# Altium schematic sheet 3_NRF52840.SchDoc(3_NRF52840)
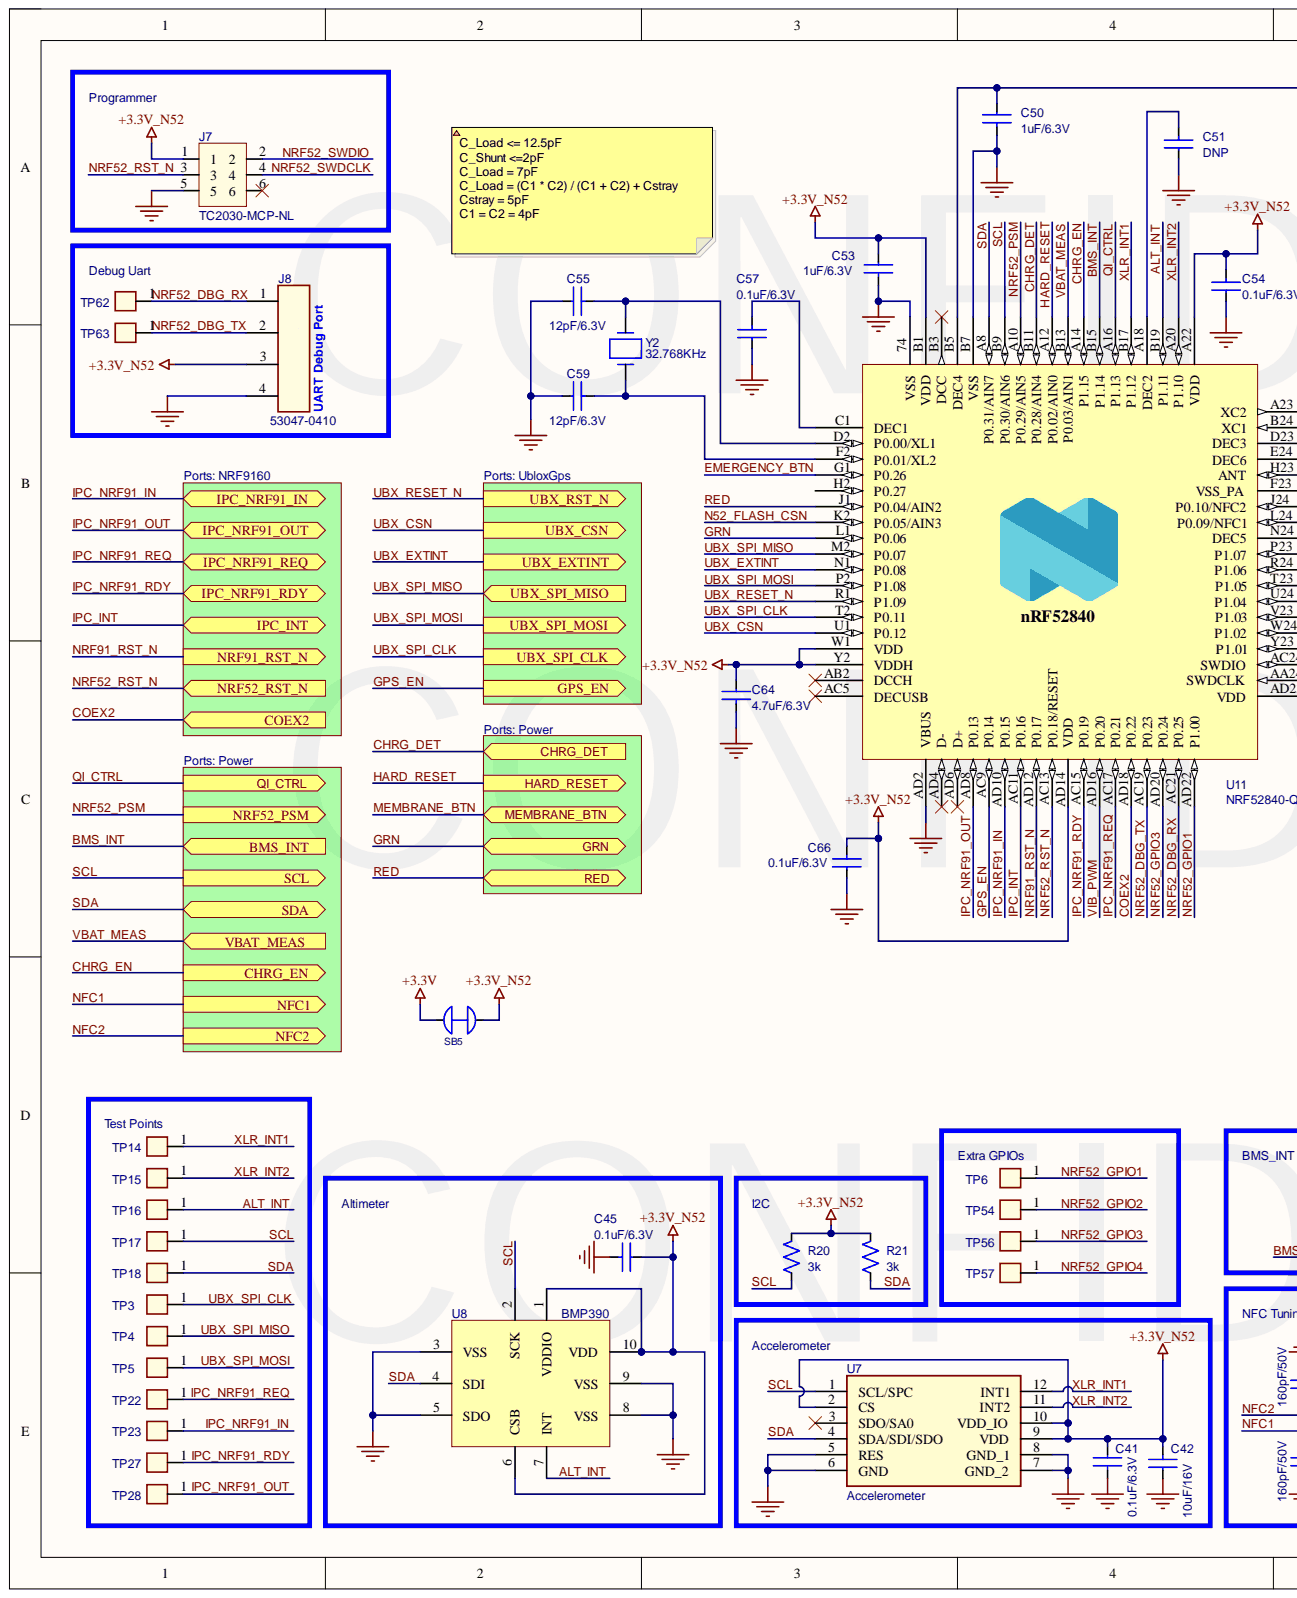
[diagram p0: 3_NRF52840.SchDoc, left]
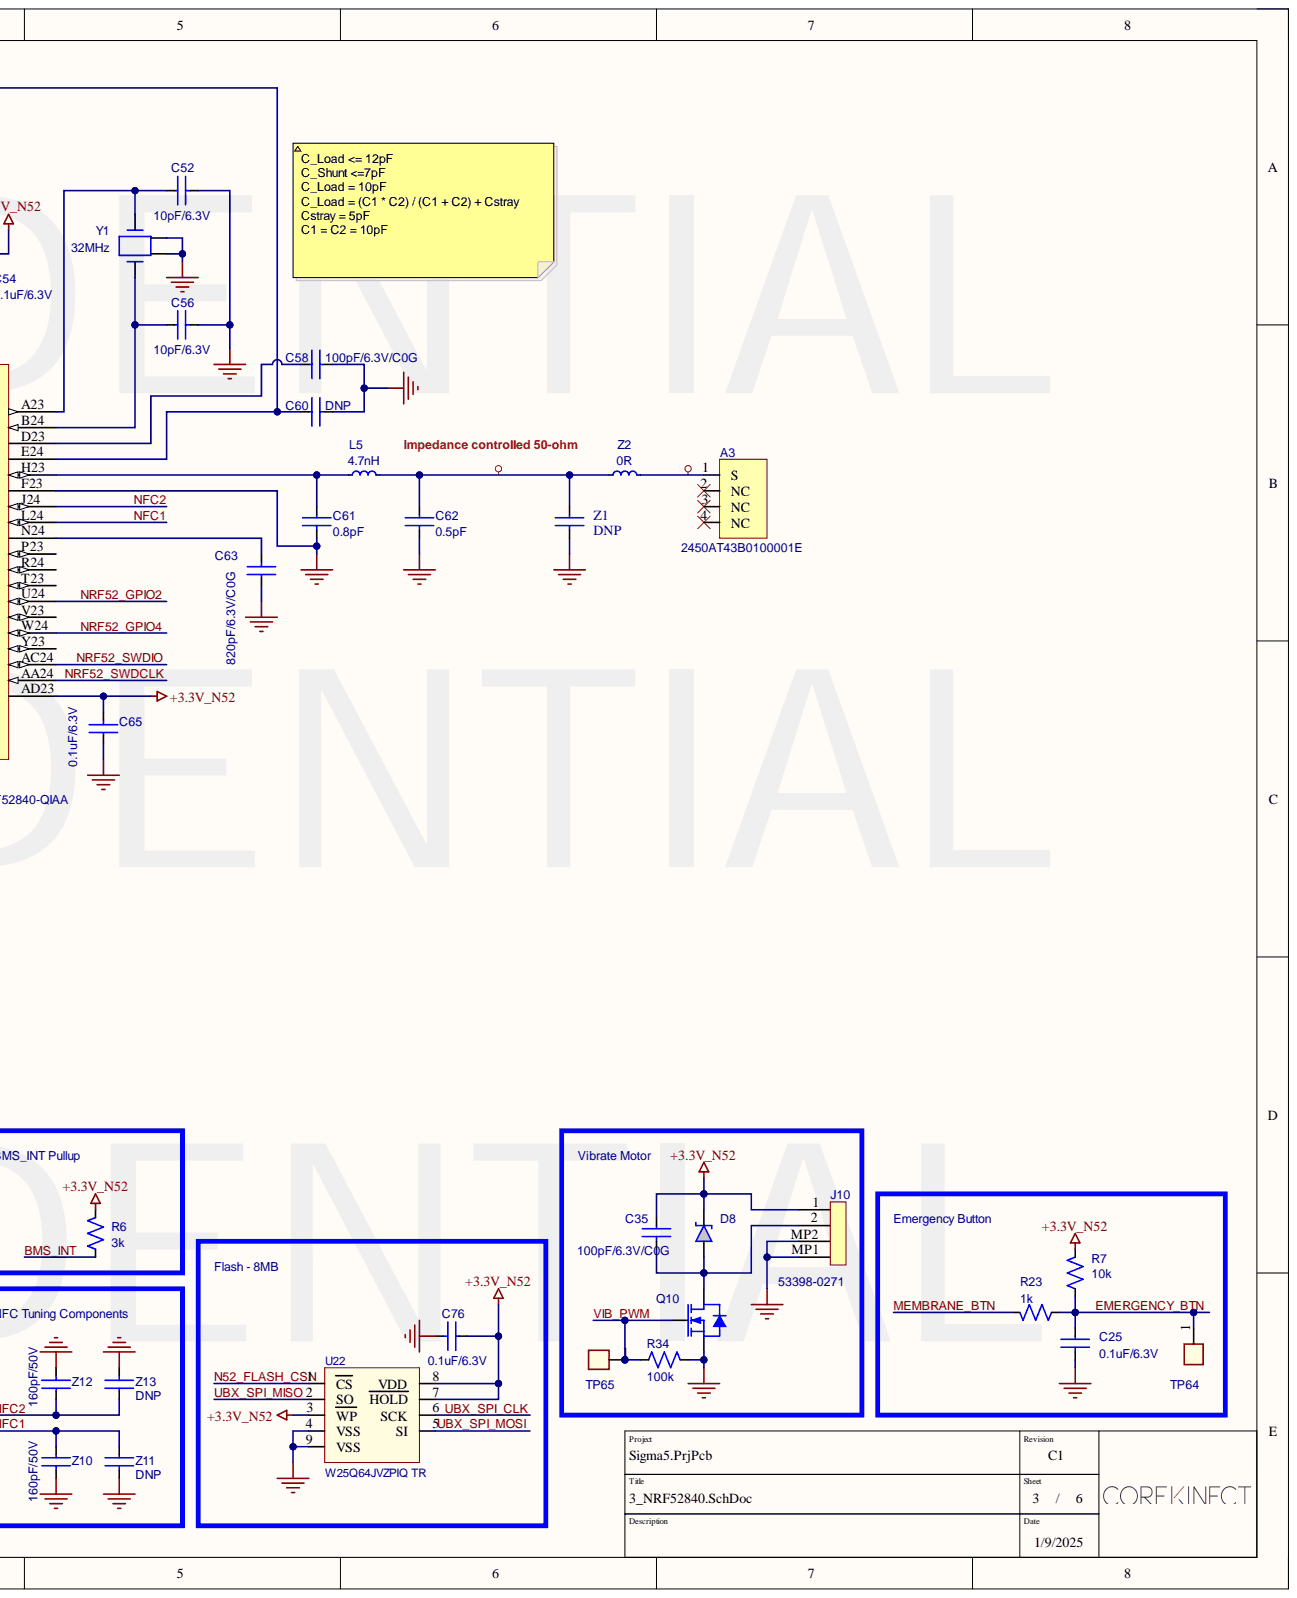
[diagram p1: 3_NRF52840.SchDoc, right]

## Parts
A3  2450AT43B0100001E  [JOHANSON_2450AT43B100]
C25  0.1uF/6.3V  [CAP_0402]
C35  100pF/6.3V/C0G  [CAP_0402]
C41  0.1uF/6.3V  [CAP_0402]
C42  10uF/16V  [CAP_0603]
C45  0.1uF/6.3V  [CAP_0402]
C50  1uF/6.3V  [CAP_0402]
C51  DNP  [CAP_0402]
C52  10pF/6.3V  [CAP_0402]
C53  1uF/6.3V  [CAP_0402]
C54  0.1uF/6.3V  [CAP_0402]
C55  12pF/6.3V  [CAP_0402]
C56  10pF/6.3V  [CAP_0402]
C57  0.1uF/6.3V  [CAP_0402]
C58  100pF/6.3V/C0G  [CAP_0402]
C59  12pF/6.3V  [CAP_0402]
C60  DNP  [CAP_0402]
C61  0.8pF  [CAP_0201]
C62  0.5pF  [CAP_0201]
C63  820pF/6.3V/C0G  [CAP_0402]
C64  4.7uF/6.3V  [CAP_0603]
C65  0.1uF/6.3V  [CAP_0402]
C66  0.1uF/6.3V  [CAP_0402]
C76  0.1uF/6.3V  [CAP_0402]
D8  B140HW-7  [SOD123]  (value not drawn)
J7  TC2030-MCP-NL  [TC2030-MCP-NL]
J8  53047-0410  [0530470410_Molex]
J10  53398-0271  [MOLEX-53398-0271_V]
L5  4.7nH  [IND_0201]
Q10  DMG1012UW-7  [SOT-323]  (value not drawn)
R6  3k  [RES_0402]
R7  10k  [RES_0402]
R20  3k  [RES_0402]
R21  3k  [RES_0402]
R23  1k  [RES_0402]
R34  100k  [RES_0402]
SB5  SOLDER BRIDGE CLOSED  [RES_0402]  (value not drawn)
TP3  TP  [SMT_TP35_SM]
TP4  TP  [SMT_TP35_SM]
TP5  TP  [SMT_TP35_SM]
TP6  TP  [SMT_TP35_SM]
TP14  TP  [SMT_TP35_SM]
TP15  TP  [SMT_TP35_SM]
TP16  TP  [SMT_TP35_SM]
TP17  TP  [SMT_TP35_SM]
TP18  TP  [SMT_TP35_SM]
TP22  TP  [SMT_TP35_SM]
TP23  TP  [SMT_TP35_SM]
TP27  TP  [SMT_TP35_SM]
TP28  TP  [SMT_TP35_SM]
TP54  TP  [SMT_TP35_SM]
TP56  TP  [SMT_TP35_SM]
TP57  TP  [SMT_TP35_SM]
TP62  TP  [SMT_TP35_SM]
TP63  TP  [SMT_TP35_SM]
TP64  TP  [SMT_TP35_SM]
TP65  TP  [SMT_TP35_SM]
U7  Accelerometer  [LGA12S50P4X4_200X200_100]
U8  BMP390  [BMP388]
U11  NRF52840-QIAA  [nRF52_AQFN-73]
U22  W25Q64JVZPIQ TR  [WSON8-6x5mm]
Y1  32MHz  [XTL4_2.5X2.0MM_SM]
Y2  32.768KHz  [XTAL_CM7V-T1A]
Z1  DNP  [CAP_0402]
Z2  0R  [CAP_0402]
Z10  160pF/50V  [CAP_0402]
Z11  DNP  [CAP_0402]
Z12  160pF/50V  [CAP_0402]
Z13  DNP  [CAP_0402]

## Ports
BMS_INT, CHRG_DET, CHRG_EN, COEX2, GPS_EN, GRN, HARD_RESET, IPC_INT, IPC_NRF91_IN, IPC_NRF91_OUT, IPC_NRF91_RDY, IPC_NRF91_REQ, MEMBRANE_BTN, NFC1, NFC2, NRF52_PSM, NRF52_RST_N, NRF91_RST_N, QI_CTRL, RED, SCL, SDA, UBX_CSN, UBX_EXTINT, UBX_RST_N, UBX_SPI_CLK, UBX_SPI_MISO, UBX_SPI_MOSI, VBAT_MEAS

## Nets
+3.3V: SB5.1
+3.3V_N52: C35.1 C41.1 C42.2 C45.1 C53.1 C54.2 C64.1 C65.2 C66.2 C76.1 D8.1 J7.1 J8.3 J10.1 R6.2 R7.2 R20.2 R21.2 SB5.2 U7.2(CS) U7.9(VDD) U7.10(VDD_IO) U8.1(VDDIO) U8.6(CSB) U8.10(VDD) U11.A22(VDD) U11.AD14(VDD) U11.AD23(VDD) U11.B1(VDD) U11.W1(VDD) U11.Y2(VDDH) U22.3(WP) U22.7(HOLD) U22.8(VDD)
GND: C25.2 C41.2 C42.1 C45.2 C50.2 C51.1 C52.2 C53.2 C54.1 C55.1 C56.2 C57.1 C58.2 C59.1 C60.2 C61.2 C62.2 C63.1 C64.2 C65.1 C66.1 C76.2 J7.5 J8.4 J10.MP1 J10.MP2 Q10.2 R34.2 U7.5(RES) U7.6(GND) U7.7(GND_2) U7.8(GND_1) U8.3(VSS) U8.5(SDO) U8.8(VSS) U8.9(VSS) U11.74(VSS) U11.AD2(VBUS) U11.B7(VSS) U11.F23(VSS_PA) U22.4(VSS) U22.9(VSS) Y1.2 Y1.4 Z1.2 Z10.2 Z11.2 Z12.2 Z13.2
ALT_INT: TP16.1 U8.7(INT) U11.B19(P1.11)
BMS_INT: R6.1 U11.B15(P1.14) ; port BMS_INT
CHRG_DET: U11.B11(P0.28/AIN4) ; port CHRG_DET
CHRG_EN: U11.A14(P1.15) ; port CHRG_EN
COEX2: U11.AD18(P0.22) ; port COEX2
EMERGENCY_BTN: C25.1 R7.1 R23.1 TP64.1 U11.G1(P0.26)
GPS_EN: U11.AC9(P0.14) ; port GPS_EN
GRN: U11.L1(P0.06) ; port GRN
HARD_RESET: U11.A12(P0.02/AIN0) ; port HARD_RESET
IPC_INT: U11.AC11(P0.16) ; port IPC_INT
IPC_NRF91_IN: TP23.1 U11.AD10(P0.15) ; port IPC_NRF91_IN
IPC_NRF91_OUT: TP28.1 U11.AD8(P0.13) ; port IPC_NRF91_OUT
IPC_NRF91_RDY: TP27.1 U11.AC15(P0.19) ; port IPC_NRF91_RDY
IPC_NRF91_REQ: TP22.1 U11.AC17(P0.21) ; port IPC_NRF91_REQ
MEMBRANE_BTN: R23.2 ; port MEMBRANE_BTN
N52_FLASH_CSN: U11.K2(P0.05/AIN3) U22.1(CS)
NFC1: U11.L24(P0.09/NFC1) Z10.1 Z11.1 ; port NFC1
NFC2: U11.J24(P0.10/NFC2) Z12.1 Z13.1 ; port NFC2
NRF52_DBG_RX: J8.1 TP62.1 U11.AC21(P0.25)
NRF52_DBG_TX: J8.2 TP63.1 U11.AC19(P0.23)
NRF52_GPIO1: TP6.1 U11.AD22(P1.00)
NRF52_GPIO2: TP54.1 U11.U24(P1.04)
NRF52_GPIO3: TP56.1 U11.AD20(P0.24)
NRF52_GPIO4: TP57.1 U11.W24(P1.02)
NRF52_PSM: U11.A10(P0.29/AIN5) ; port NRF52_PSM
NRF52_RST_N: J7.3 U11.AC13(P0.18/RESET) ; port NRF52_RST_N
NRF52_SWDCLK: J7.4 U11.AA24(SWDCLK)
NRF52_SWDIO: J7.2 U11.AC24(SWDIO)
NRF91_RST_N: U11.AD12(P0.17) ; port NRF91_RST_N
QI_CTRL: U11.A16(P1.13) ; port QI_CTRL
RED: U11.J1(P0.04/AIN2) ; port RED
SCL: R20.1 TP17.1 U7.1(SCL/SPC) U8.2(SCK) U11.B9(P0.30/AIN6) ; port SCL
SDA: R21.1 TP18.1 U7.4(SDA/SDI/SDO) U8.4(SDI) U11.A8(P0.31/AIN7) ; port SDA
UBX_CSN: U11.U1(P0.12) ; port UBX_CSN
UBX_EXTINT: U11.N1(P0.08) ; port UBX_EXTINT
UBX_RESET_N: U11.R1(P1.09) ; port UBX_RST_N
UBX_SPI_CLK: TP3.1 U11.T2(P0.11) U22.6(SCK) ; port UBX_SPI_CLK
UBX_SPI_MISO: TP4.1 U11.M2(P0.07) U22.2(SO) ; port UBX_SPI_MISO
UBX_SPI_MOSI: TP5.1 U11.P2(P1.08) U22.5(SI) ; port UBX_SPI_MOSI
VBAT_MEAS: U11.B13(P0.03/AIN1) ; port VBAT_MEAS
VIB_PWM: Q10.1 R34.1 TP65.1 U11.AD16(P0.20)
XLR_INT1: TP14.1 U7.12(INT1) U11.B17(P1.12)
XLR_INT2: TP15.1 U7.11(INT2) U11.A20(P1.10)
NetA3_1: A3.1(S) Z2.2
NetC35_2: C35.2 D8.2 J10.2 Q10.3
NetC50_1: C50.1 C60.1 U11.B5(DEC4) U11.E24(DEC6)
NetC51_2: C51.2 U11.A18(DEC2)
NetC52_1: C52.1 U11.A23(XC2) Y1.1
NetC55_2: C55.2 U11.D2(P0.00/XL1) Y2.2
NetC56_1: C56.1 U11.B24(XC1) Y1.3
NetC57_2: C57.2 U11.C1(DEC1)
NetC58_1: C58.1 U11.D23(DEC3)
NetC59_2: C59.2 U11.F2(P0.01/XL2) Y2.1
NetC61_1: C61.1 L5.1 U11.H23(ANT)
NetC62_1: C62.1 L5.2 Z1.1 Z2.1
NetC63_2: C63.2 U11.N24(DEC5)

Not connected: A3 2(NC) 3(NC) 4(NC); J7 6; U7 3(SDO/SA0); U11 AB2(DCCH) AC5(DECUSB) AD4(D-) AD6(D+) B3(DCC) H2(P0.27) P23(P1.07) R24(P1.06) T23(P1.05) V23(P1.03) Y23(P1.01)

## Library data of the parts
A3 = 2450AT43B100E: High Frequency Ceramic Solutions 4-Pin SMD T/R; Manufacturer 1: Johanson; Frequency: 2.4GHz; Gain: -0.5dB; Impedance: 50R; Max Frequency: 2.5GHz; Max Power Dissipation: 2W; Notes: BLE antenna; Number of Bands: 1; Return Loss: -9.5dB; Case/Package: SMD/SMT; Category: RF Antennas; Component Kind: Standard; ComponentLink1Description: https://datasheet.ciiva.com/24068/2450at43b100-242468-24068034.pdf?src-supplier=Mouser; ComponentLink1URL: https://datasheet.ciiva.com/24068/2450at43b100-242468-24068034.pdf?src-supplier=Mouser; ComponentLink2Description: https://datasheet.ciiva.com/2792/jti-antenna-2450at43b100-09042008-2792593.pdf?src-supplier=Digi-Key; ComponentLink2URL: https://datasheet.ciiva.com/2792/jti-antenna-2450at43b100-09042008-2792593.pdf?src-supplier=Digi-Key; ComponentLink3Description: https://datasheet.ciiva.com/7633/1860765-7633973.pdf?src-supplier=Element14; ComponentLink3URL: https://datasheet.ciiva.com/7633/1860765-7633973.pdf?src-supplier=Element14; Contact Plating: Tin; Height: 0.079inch; Length: 7mm; Manufacturer Part Number 1: 2450AT43B0100001E; Mount: Surface Mount; Number of Pins: 4; Package Quantity: 1; Packaging: Tape and Reel; Power Rating: 2W; Pricing 1: 1=0.71000, 10=0.62400, 25=0.58600, 100=0.47820, 250=0.44416, 500=0.37800 (USD); Radiation Hardening: No; REACH SVHC: Unknown; RoHS: Yes; Stock 1: 8574; Supplier 1: Digi-Key; Supplier Part Number 1: 712-1010-1-ND; Termination: Solder; Width: 2mm; Library Name: CoreKinectIntegratedLibrary.IntLib
C25 C35 C41 C45 C50-C60 C63 C65 C66 C76 Z10-Z13 = CAP_0402: Component Kind: Standard; Package / Case: 0402; Library Name: CoreKinectIntegratedLibrary.IntLib
C42 C64 = CAP_0603: Component Kind: Standard; Package / Case: 0603; Library Name: CoreKinectIntegratedLibrary.IntLib
C61 C62 = CAP_0201: Notes: BLE tuning - High Q; Component Kind: Standard; Package / Case: 0201; Library Name: CoreKinectIntegratedLibrary.IntLib
D8 = B140HW-7: Diode Schottky 40V 1A 2Pin SOD123; Manufacturer 1: Diodes; Element Configuration: Single; Forward Voltage: 550mV; Max Forward Surge Current (Ifsm): 16A; Max Power Dissipation: 410mW; Max Reverse Current: 40uA; Polarity: Standard; Average Rectified Current: 1A; Category: Rectifier Diodes; Component Kind: Standard; ComponentLink1Description: https://datasheet.ciiva.com/25946/ds30670-25946913.pdf?src-supplier=Avnet+Europe; ComponentLink1URL: https://datasheet.ciiva.com/25946/ds30670-25946913.pdf?src-supplier=Avnet+Europe; ComponentLink2Description: https://datasheet.ciiva.com/4715/ds30670-86720-4715619.pdf?src-supplier=Mouser; ComponentLink2URL: https://datasheet.ciiva.com/4715/ds30670-86720-4715619.pdf?src-supplier=Mouser; ComponentLink3Description: https://datasheet.ciiva.com/26788/b140hw-7-26788582.pdf?src-supplier=IIIC; ComponentLink3URL: https://datasheet.ciiva.com/26788/b140hw-7-26788582.pdf?src-supplier=IIIC; ComponentLink4Description: https://datasheet.ciiva.com/912/ds30670-912807.pdf?src-supplier=Digi-Key; ComponentLink4URL: https://datasheet.ciiva.com/912/ds30670-912807.pdf?src-supplier=Digi-Key; ComponentLink5Description: https://datasheet.ciiva.com/912/ds30670-912808.pdf?src-supplier=Digi-Key; ComponentLink5URL: https://datasheet.ciiva.com/912/ds30670-912808.pdf?src-supplier=Digi-Key; ComponentLink6Description: https://datasheet.ciiva.com/912/ds30670-912809.pdf?src-supplier=Digi-Key; ComponentLink6URL: https://datasheet.ciiva.com/912/ds30670-912809.pdf?src-supplier=Digi-Key; ComponentLink7Description: https://datasheet.ciiva.com/8301/ds30670-8301643.pdf?src-supplier=Avnet; ComponentLink7URL: https://datasheet.ciiva.com/8301/ds30670-8301643.pdf?src-supplier=Avnet; ComponentLink8Description: https://datasheet.ciiva.com/8303/ds30670-8303271.pdf?src-supplier=Avnet; ComponentLink8URL: https://datasheet.ciiva.com/8303/ds30670-8303271.pdf?src-supplier=Avnet; ComponentLink9Description: https://datasheet.ciiva.com/1177/ds30670-1177415.pdf?src-supplier=Element14; ComponentLink9URL: https://datasheet.ciiva.com/1177/ds30670-1177415.pdf?src-supplier=Element14; ComponentLink10Description: https://datasheet.ciiva.com/15220/getdatasheetpartid-245799-15220198.pdf?src-supplier=Verical; ComponentLink10URL: https://datasheet.ciiva.com/15220/getdatasheetpartid-245799-15220198.pdf?src-supplier=Verical; Contact Plating: Tin,Matte; Current Rating: 1A; Forward Current: 1A; Height: 1.25mm; Lead Free: Lead Free; Length: 2.85mm; Manufacturer Part Number 1: B140HW-7; Max Operating Temperature: 125°C; Max Repetitive Reverse Voltage (Vrrm): 40V; Max Reverse Voltage (DC): 40V; Min Operating Temperature: -65°C; Mount: Surface Mount; Number of Pins: 2; Output Current: 1A; Package Quantity: 3000; Packaging: Tape and Reel; Peak Non-Repetitive Surge Current: 16A; Pricing 1: 3000=0.08800, 6000=0.07920, 15000=0.07040, 30000=0.06680 (USD); Radiation Hardening: No; REACH SVHC: No SVHC; RoHS: Yes; RoHS Compliant: Yes; Series: -; Stock 1: 84000; Supplier 1: Digi-Key; Supplier Part Number 1: B140HWDITR-ND; Voltage Rating (DC): 40V; Weight: 0.000353oz; Width: 1.7mm; Library Name: CoreKinectIntegratedLibrary.IntLib
J7 = TC2030-MCP-NL: CABLE TAG-CONNECT IN-CIRCUIT; Component Kind: Standard; PN: TC2030-MCP-NL; Library Name: Project Zenith.SchLib
J8 = MOLEX_53047-0410: GLOVE, POLYAMIDE, L/FREE, PU, SIZE 6 - More Details; Manufacturer 1: Molex; Contact Resistance: 20mR; Current: 1A; Gender: Male; Glow Wire Compliant: Non-compliant; Insulation Resistance: 100MR; Max Voltage Rating (AC): 125V; Number of Circuits: 4; Pitch: 1.25mm; Plating: Tin; Case/Package: 0410; Category: Headers and Wire Housings; Color: Natural; Component Kind: Standard; ComponentLink1Description: https://datasheet.ciiva.com/7844/1838335-7844032.pdf?src-supplier=Element14; ComponentLink1URL: https://datasheet.ciiva.com/7844/1838335-7844032.pdf?src-supplier=Element14; ComponentLink2Description: https://datasheet.ciiva.com/30144/347020530470410-pcb-headers-30144214.pdf?src-supplier=Onlinecomponents.com; ComponentLink2URL: https://datasheet.ciiva.com/30144/347020530470410-pcb-headers-30144214.pdf?src-supplier=Onlinecomponents.com; ComponentLink3Description: https://datasheet.ciiva.com/20207/22-3930e-20207527.pdf?src-supplier=Rapid+Electronics; ComponentLink3URL: https://datasheet.ciiva.com/20207/22-3930e-20207527.pdf?src-supplier=Rapid+Electronics; ComponentLink4Description: https://datasheet.ciiva.com/5149/0530470410-pcb-headers-170953-5149004.pdf?src-supplier=Mouser; ComponentLink4URL: https://datasheet.ciiva.com/5149/0530470410-pcb-headers-170953-5149004.pdf?src-supplier=Mouser; ComponentLink5Description: https://datasheet.ciiva.com/11746/0900766b8024e2fd-11746351.pdf?src-supplier=RSComponents; ComponentLink5URL: https://datasheet.ciiva.com/11746/0900766b8024e2fd-11746351.pdf?src-supplier=RSComponents; ComponentLink6Description: https://datasheet.ciiva.com/16658/0530470410-pcb-headers-16658419.pdf?src-supplier=Avnet+Europe; ComponentLink6URL: https://datasheet.ciiva.com/16658/0530470410-pcb-headers-16658419.pdf?src-supplier=Avnet+Europe; ComponentLink7Description: https://datasheet.ciiva.com/27054/1932483-27054996.pdf?src-supplier=Jameco; ComponentLink7URL: https://datasheet.ciiva.com/27054/1932483-27054996.pdf?src-supplier=Jameco; ComponentLink8Description: https://datasheet.ciiva.com/14156/ge116819-116832-e-14156235.pdf?src-supplier=Distrelec; ComponentLink8URL: https://datasheet.ciiva.com/14156/ge116819-116832-e-14156235.pdf?src-supplier=Distrelec; ComponentLink9Description: https://datasheet.ciiva.com/10088/0530470410-pcb-headers-10088984.pdf?src-supplier=Avnet; ComponentLink9URL: https://datasheet.ciiva.com/10088/0530470410-pcb-headers-10088984.pdf?src-supplier=Avnet; Contact Material: Bronze,Tin; Contact Plating: Tin; Current Rating: 1A; Flammability Rating: UL94 V-0; Housing Color: Natural; Housing Material: Polyamide; Lead Free: Lead Free; Manufacturer Part Number 1: 53047-0410; Max Operating Temperature: 85°C; Min Operating Temperature: -40°C; Mount: Through Hole; Number of Contacts: 4; Number of Positions: 4; Number of Rows: 1; Orientation: Vertical; Package Quantity: 5000; Packaging: Tray; Pricing 1: 1=0.28000, 10=0.26100, 100=0.18300, 500=0.15688, 1000=0.13073, 5000=0.11205, 10000=0.10458 (USD); Radiation Hardening: No; REACH SVHC: Unknown; RoHS: Yes; Stock 1: 47330; Supplier 1: Digi-Key; Supplier Part Number 1: WM1733-ND; Termination: Solder; Voltage: 125V; Voltage Rating: 125V; Voltage Rating (AC): 125V; Voltage Rating (DC): 125V; Working Voltage: 125V; Library Name: CoreKinectIntegratedLibrary.IntLib
J10 = MOLEX_53398-0271: 1.25mm (.049") Pitch PicoBlade Header, Surface Mount, Vertical, Lead-free, 2 Circuits; Manufacturer: Molex; Contact Resistance: 20mR; Current Rating: 1A; Gender: Male; Glow Wire Compliant: Non-compliant; Insulation Resistance: 100MR; Number of Circuits: 2; Voltage Rating: 125V; Category: Headers and Wire Housings; Color: Natural; Component Kind: Standard; Contact Material: Bronze,Brass,Tin; Contact Plating: Tin; Contact Type: Blade Pin; Flammability Rating: UL94 V-0; Housing Color: Natural; Housing Material: Nylon; Lead Free: Lead Free; Manufacturer Part Number: 53398-0271; Max Operating Temperature: 85°C; Min Operating Temperature: -40°C; Mount: Surface Mount; Number of Contacts: 2; Number of Positions: 2; Number of Rows: 1; Orientation: Vertical; Package Quantity: 1000; Packaging: Tape and Reel; Pitch: 1.25mm; Pricing 1: 1=0.65, 10=0.551, 100=0.4284 (USD); Radiation Hardening: No; Reach SVHC Compliant: Unknown; RoHS: Yes; RoHS Compliant: Yes; Series: PicoBlade; Stock 1: 149643; Supplier 1: Digi-Key; Supplier Part Number 1: WM7606DKR-ND; Termination: Solder; Voltage Rating (AC): 125V; Voltage Rating (DC): 125V; Working Voltage: 125V; Library Name: CoreKinectIntegratedLibrary.IntLib
L5 = IND_0201: Notes: BLE tuning - High Q; Component Kind: Standard; Package / Case: 0201; Library Name: CoreKinectIntegratedLibrary.IntLib
Q10 = DMG1012UW-7: MOSFET N-CH 20V 1A SOT323; Manufacturer: Diodes Zetex; Drain to Source Resistance: 300mR; Fall Time: 12.3ns; Gate to Source Voltage (Vgs): 6V; Input Capacitance: 60.67pF; Max Power Dissipation: 290mW; Number of Channels: 1; Polarity: N-CHANNEL; Rds On Max: 450mR; Rise Time: 7.4ns; Turn-Off Delay Time: 26.7ns; Turn-On Delay Time: 5.1ns; Case/Package: SOT-323; Category: MOSFETs; Component Kind: Standard; ComponentLink1Description: https://datasheet.ciiva.com/4443/ds31859-90313-4443117.pdf?src-supplier=Mouser; ComponentLink1URL: https://datasheet.ciiva.com/4443/ds31859-90313-4443117.pdf?src-supplier=Mouser; ComponentLink2Description: https://datasheet.ciiva.com/11174/ds31859-11174966.pdf?src-supplier=Avnet; ComponentLink2URL: https://datasheet.ciiva.com/11174/ds31859-11174966.pdf?src-supplier=Avnet; ComponentLink3Description: https://datasheet.ciiva.com/6383/ds31859-6383798.pdf?src-supplier=Digi-Key; ComponentLink3URL: https://datasheet.ciiva.com/6383/ds31859-6383798.pdf?src-supplier=Digi-Key; ComponentLink4Description: https://datasheet.ciiva.com/6383/ds31859-6383800.pdf?src-supplier=Digi-Key; ComponentLink4URL: https://datasheet.ciiva.com/6383/ds31859-6383800.pdf?src-supplier=Digi-Key; Continuous Drain Current (ID): 1A; Drain to Source Voltage (Vdss): 20V; Height: 1mm; Lead Free: Lead Free; Length: 2.2mm; Manufacturer Part Number: DMG1012UW-7; Max Operating Temperature: 150°C; Min Operating Temperature: -55°C; Mount: Surface Mount; Number of Pins: 3; Package Quantity: 3000; Packaging: Tape and Reel; Pricing 1: 1=0.35, 10=0.283, 100=0.1499, 500=0.09862, 1000=0.06706 (USD); Radiation Hardening: No; Reach SVHC Compliant: Yes; RoHS: Yes; RoHS Compliant: Yes; Series: -; Stock 1: 770121; Supplier 1: Digi-Key; Supplier Part Number 1: DMG1012UW-7DICT-ND; Weight: 0.000212oz; Width: 1.35mm; Library Name: CoreKinectIntegratedLibrary.IntLib
R6 R7 R20 R21 R23 R34 = RES_0402: Component Kind: Standard; Package / Case: 0402; Library Name: CoreKinectIntegratedLibrary.IntLib
SB5 = MISC-00000B: Short circuit 0402; Manufacturer 1: CoreKinect; Manufacturer Part Number 1: Short-Circuit; Database Table Name: Misc; Component Kind: Net Tie; CPN: MISC-00000B; Library Name: CoreKinectDatabaseLibrary.DbLib
TP3-TP6 TP14-TP18 TP22 TP23 TP27 TP28 TP54 TP56 TP57 TP62-TP65 = CON1_1: PN: SMT_TP35; Component Kind: Standard
U7 = LIS2DE12TR: Accelerometer Triple ±2g/±4g/±8g/±16g 2.5V 12-Pin LGA T/R; Manufacturer 1: STMicroelectronics; Axis: X, Y, Z; Bandwidth: 0.5Hz; Max Supply Voltage: 3.6V; Min Supply Voltage: 1.7V; Output Type: SPI,I2C,Digital; PN: IIS2DLPCTR-7K4G; Case/Package: LGA; Category: Accelerometers; Component Kind: Standard; ComponentLink1Description: http://www.farnell.com/datasheets/2028147.pdf?src-supplier=Farnell; ComponentLink1URL: http://www.farnell.com/datasheets/2028147.pdf?src-supplier=Farnell; ComponentLink2Description: https://www.mouser.com/ds/2/389/lis2de12-954977.pdf?src-supplier=Mouser; ComponentLink2URL: https://www.mouser.com/ds/2/389/lis2de12-954977.pdf?src-supplier=Mouser; ComponentLink3Description: http://www.st.com/content/ccc/resource/technical/document/datasheet/group0/72/cb/80/a7/5e/fe/46/9d/DM00153214/files/DM00153214.pdf/jcr:content/translations/en.DM00153214.pdf?src-supplier=Digi-Key; ComponentLink3URL: http://www.st.com/content/ccc/resource/technical/document/datasheet/group0/72/cb/80/a7/5e/fe/46/9d/DM00153214/files/DM00153214.pdf/jcr:content/translations/en.DM00153214.pdf?src-supplier=Digi-Key; ComponentLink4Description: http://www.st.com/st-web-ui/static/active/en/resource/technical/document/datasheet/DM00153214.pdf?src-supplier=Avnet; ComponentLink4URL: http://www.st.com/st-web-ui/static/active/en/resource/technical/document/datasheet/DM00153214.pdf?src-supplier=Avnet; ComponentLink5Description: http://www.farnell.com/datasheets/2028147.pdf?src-supplier=Element14; ComponentLink5URL: http://www.farnell.com/datasheets/2028147.pdf?src-supplier=Element14; ComponentLink6Description: http://www.st.com/st-web-ui/static/active/en/resource/technical/document/datasheet/DM00153214.pdf?src-supplier=Avnet+Asia; ComponentLink6URL: http://www.st.com/st-web-ui/static/active/en/resource/technical/document/datasheet/DM00153214.pdf?src-supplier=Avnet+Asia; Contact Plating: Gold; Lead Free: Lead Free; Manufacturer Part Number 1: LIS2DE12TR; Max Operating Temperature: 85°C; Min Operating Temperature: -40°C; Mount: Surface Mount; Number of Pins: 12; Packaging: Cut Tape; Pricing 1: 1=1.45000, 10=1.29800, 25=1.23200, 100=1.01200, 500=0.83600, 1000=0.66000 (USD); REACH SVHC: No SVHC; RoHS: Yes; Stock 1: 24818; Supplier 1: Digi-Key; Supplier Part Number 1: 497-15997-1-ND; Library Name: CoreKinectIntegratedLibrary.IntLib
U8 = BMP388: Pressure Sensor 30kPa to 125kPa Absolute 10-Pin LGA; Manufacturer 1: Bosch Sensortec; Capacitance: 4.7uF; Continuous Drain Current (ID): 4A; Drain to Source Voltage (Vdss): 30V; Input Capacitance: 645pF; Max Power Dissipation: 1.4W; Operating Pressure: 18.13PSI; Output Type: SPI,I2C; Port Style: No Port; Rds On Max: 50mR; Tolerance: 20%; Voltage Rating: 16V; Case Code (Imperial): 0805; Case Code (Metric): 2012; Case/Package: LGA; Category: Pressure Sensors; Component Kind: Standard; ComponentLink1Description: https://ae-bst.resource.bosch.com/media/_tech/media/datasheets/BST-BMP388-DS001.pdf?src-supplier=Digi-Key; ComponentLink1URL: https://ae-bst.resource.bosch.com/media/_tech/media/datasheets/BST-BMP388-DS001.pdf?src-supplier=Digi-Key; ComponentLink2Description: https://ae-bst.resource.bosch.com/media/_tech/media/product_flyer/BST-BMP388-FL000-00.pdf?src-supplier=Digi-Key; ComponentLink2URL: https://ae-bst.resource.bosch.com/media/_tech/media/product_flyer/BST-BMP388-FL000-00.pdf?src-supplier=Digi-Key; ComponentLink3Description: https://ae-bst.resource.bosch.com/media/_tech/media/application_notes/BST-BMP380-HS000.pdf?src-supplier=Digi-Key; ComponentLink3URL: https://ae-bst.resource.bosch.com/media/_tech/media/application_notes/BST-BMP380-HS000.pdf?src-supplier=Digi-Key; Features: Soft Termination; Manufacturer Part Number 1: BMP390; Max Operating Temperature: 150°C; Min Operating Temperature: -55°C; Mount: Surface Mount; Number of Pins: 10; Packaging: Tape and Reel; Pressure Type: Absolute; Pricing 1: 1=3.81000, 5=3.36600, 10=2.97300, 25=2.41240, 50=2.13180, 100=2.07570, 500=1.73910, 1000=1.62690, 5000=1.51470 (USD); Ratings: AEC-Q200; RoHS: No; Stock 1: 31368; Supplier 1: Digi-Key; Supplier Part Number 1: 828-1079-1-ND; Termination: SMD/SMT; Library Name: CoreKinectIntegratedLibrary.IntLib
U11 = NRF52840-QIAA: High-end multiprotocol Bluetooth 5/Bluetooth mesh/Thread/802.15.4/ANT/2.4GHz; Manufacturer 1: Nordic Semiconductor; Manufacturer Part Number 1: NRF52840-QIAA-R7; Category: RF Receivers, Transceivers; Component Kind: Standard; Pricing 1: 1=7.21000, 10=6.43500, 25=5.79160, 100=5.27670, 250=4.76192 (USD); RoHS: Yes; Supplier 1: Digi-Key; Supplier Part Number 1: 1490-NRF52840-QIAA-R7DKR-ND; Library Name: CoreKinectIntegratedLibrary.IntLib
U22 = STRG-00000B: FLASH - NOR Memory IC 64Mbit SPI; Manufacturer 1: Winbond; Database Table Name: Storage; Component Kind: Standard; CPN: STRG-00000B; Manufacturer Part Number 1: W25Q64JVZPIQ TR; Library Name: CoreKinectDatabaseLibrary.DbLib
Y1 = JXS22-WA: CRYSTAL 32MHZ 10PF SMD; Manufacturer 1: Jauch; Manufacturer Part Number 1: Q 32,0-JXS22-10-10/10-FU-WA-LF; Component Kind: Standard; RoHS: No; Supplier 1: Digi-Key; Supplier Part Number 1: 1908-1292-1-ND; Library Name: CoreKinectIntegratedLibrary.IntLib
Y2 = CM7V-T1A: Crystal 32.768KHZ 7.0PF SMD; Manufacturer 1: Micro Crystal; Manufacturer Part Number 1: CM7V-T1A-32.768KHZ-7PF-20PPM-TA-QC; Component Kind: Standard; Pricing 1: 1000=0.19840, 3000=0.18600, 5000=0.17980, 10000=0.17360 (USD); RoHS: No; Stock 1: 4000; Supplier 1: Digi-Key; Supplier Part Number 1: 2195-CM7V-T1A-32.768KHZ-6PF-20PPM-TA-QCTR-ND; Library Name: CoreKinectIntegratedLibrary.IntLib
Z1 = CAP_0402: Notes: GNSS tuning - High Q; Component Kind: Standard; Package / Case: 0402; Library Name: CoreKinectIntegratedLibrary.IntLib
Z2 = IND_0402: Notes: LTE tuning - High Q; Component Kind: Standard; Package / Case: 0402; Library Name: CoreKinectIntegratedLibrary.IntLib
